# Altium schematic sheet BSPD.SchDoc
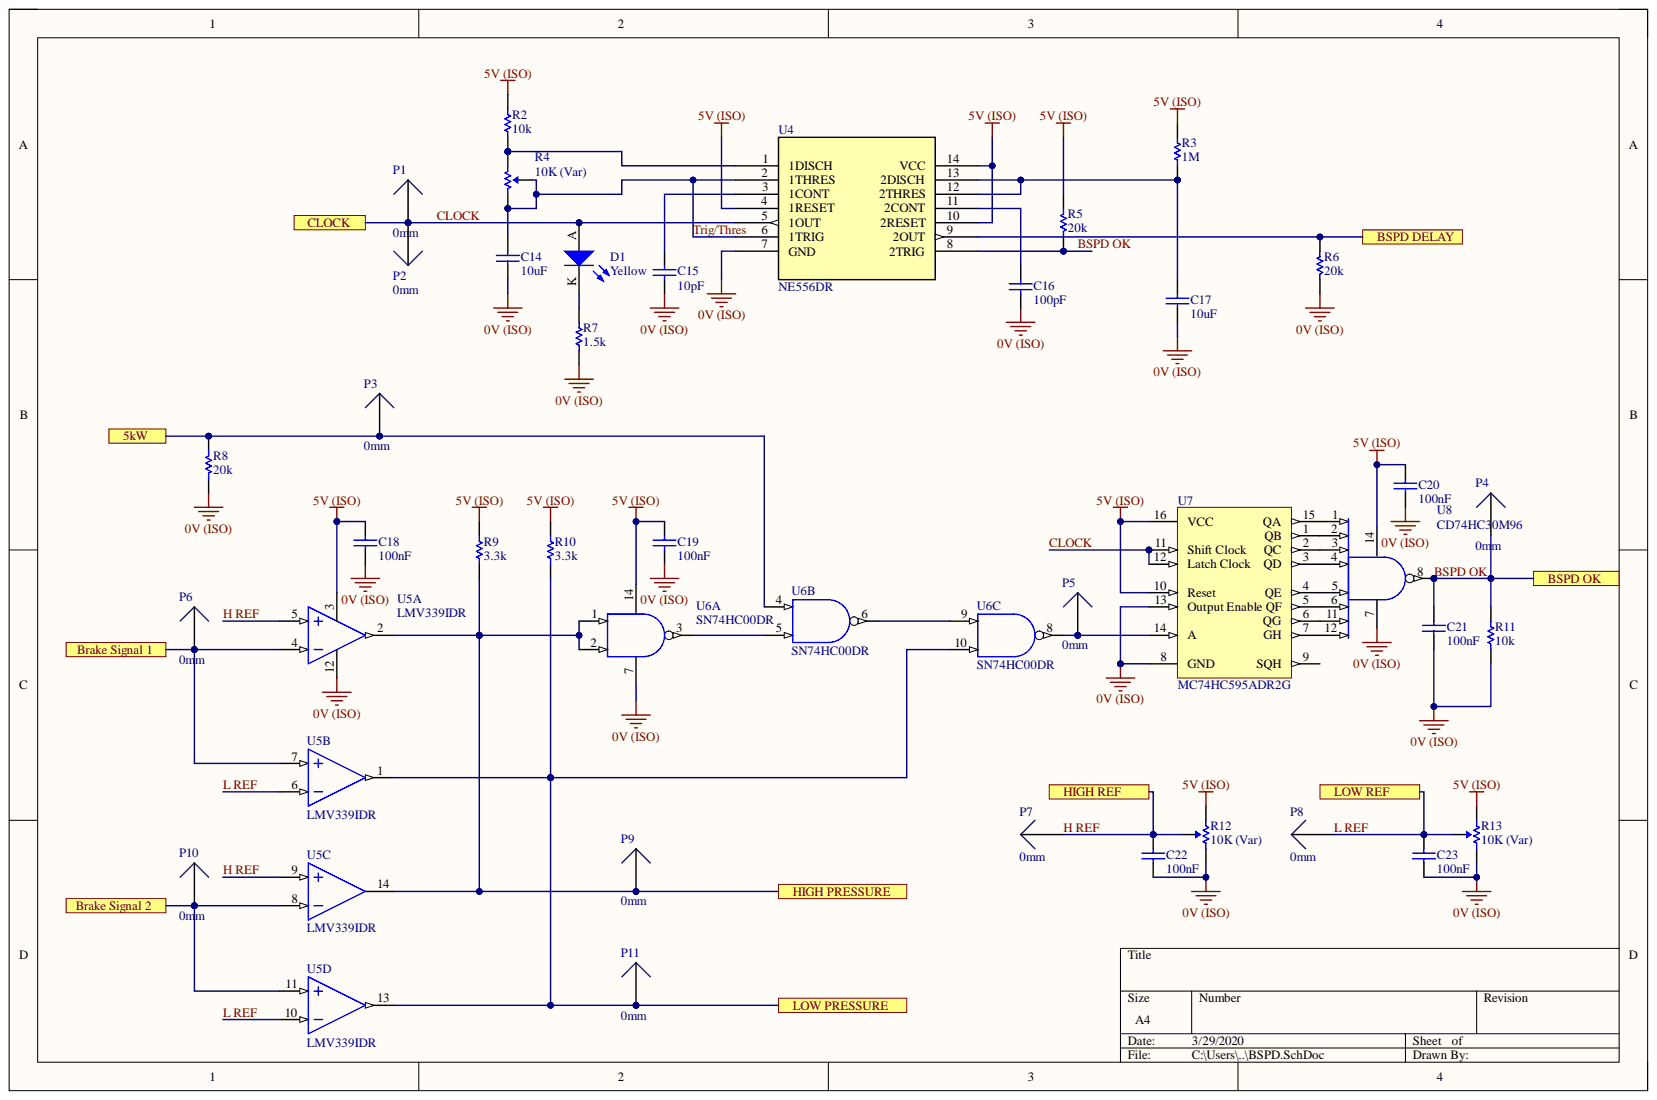
[diagram p0: BSPD.SchDoc]

## Parts
C14  10uF  [0805]
C15  10pF  [0805]
C16  100pF  [0805]
C17  10uF  [0805]
C18  100nF  [0805]
C19  100nF  [0805]
C20  100nF  [0805]
C21  100nF  [0805]
C22  100nF  [0805]
C23  100nF  [0805]
D1  Yellow  [0805 LED]
P1  0mm  [SMD Test Point]
P2  0mm  [TH Test Point]
P3  0mm  [SMD Test Point]
P4  0mm  [SMD Test Point]
P5  0mm  [SMD Test Point]
P6  0mm  [TH Test Point]
P7  0mm  [TH Test Point]
P8  0mm  [TH Test Point]
P9  0mm  [SMD Test Point]
P10  0mm  [TH Test Point]
P11  0mm  [SMD Test Point]
R2  10k  [0805]
R3  1M  [0805]
R4  10K (Var)  [B 3296]
R5  20k  [0805]
R6  20k  [0805]
R7  1.5k  [0805]
R8  20k  [0805]
R9  3.3k  [0805]
R10  3.3k  [0805]
R11  10k  [0805]
R12  10K (Var)  [B 3296]
R13  10K (Var)  [B 3296]
U4  NE556DR  [TI 14-SOIC]
U5  LMV339IDR  [TI 14-SOIC]  (parts A,B,C,D)
U6  SN74HC00DR  [TI 14-SOIC]  (parts A,B,C)
U7  MC74HC595ADR2G  [ON 16-SOIC]
U8  CD74HC30M96  [TI 14-SOIC]

## Ports
5kW, Brake Signal 1, Brake Signal 2, BSPD DELAY, BSPD OK, CLOCK, HIGH PRESSURE, HIGH REF, LOW PRESSURE, LOW REF

## Nets
0V (ISO): C14.1 C15.1 C16.1 C17.1 C18.1 C19.1 C20.1 C21.1 C22.1 C23.1 R6.2 R7.1 R8.2 R11.1 R12.1 R13.1 U4.7(GND) U5.12 U6.7 U7.8(GND) U7.13 U8.7
5V (ISO): C18.2 C19.2 C20.2 R2.2 R3.2 R5.1 R9.2 R10.2 R12.3 R13.3 U4.4(1RESET) U4.10(2RESET) U4.14(VCC) U5.3 U6.14 U7.10(Reset) U7.16(VCC) U8.14
5kW: P3.1 R8.1 U6.4 ; port 5kW
Brake Signal 1: P6.1 U5.4 U5.7 ; port Brake Signal 1
Brake Signal 2: P10.1 U5.8 U5.11 ; port Brake Signal 2
BSPD DELAY: R6.1 U4.9(2OUT) ; port BSPD DELAY
BSPD OK: C21.2 P4.1 R5.2 R11.2 U4.8(2TRIG) U8.8 ; port BSPD OK
CLOCK: D1.A P1.1 P2.1 U4.5(1OUT) U7.11(Shift_Clock) U7.12 ; port CLOCK
H REF: C22.2 P7.1 R12.2 U5.5 U5.9 ; port HIGH REF
HIGH PRESSURE: P9.1 R9.1 U5.2 U5.14 U6.1 U6.2 ; port HIGH PRESSURE
L REF: C23.2 P8.1 R13.2 U5.6 U5.10 ; port LOW REF
LOW PRESSURE: P11.1 R10.1 U5.1 U5.13 U6.10 ; port LOW PRESSURE
Trig/Thres: C14.2 R4.1 R4.2 U4.2(1THRES) U4.6(1TRIG)
NetC15_2: C15.2 U4.3(1CONT)
NetC16_2: C16.2 U4.11(2CONT)
NetC17_2: C17.2 R3.1 U4.12(2THRES) U4.13(2DISCH)
NetD1_K: D1.K R7.2
NetP5_1: P5.1 U6.8 U7.14(A)
NetR2_1: R2.1 R4.3 U4.1(1DISCH)
NetU6_3: U6.3 U6.5
NetU6_6: U6.6 U6.9
NetU7_1: U7.1(QB) U8.2
NetU7_2: U7.2(QC) U8.3
NetU7_3: U7.3(QD) U8.4
NetU7_4: U7.4(QE) U8.5
NetU7_5: U7.5(Enable_QF) U8.6
NetU7_6: U7.6(QG) U8.11
NetU7_7: U7.7(GH) U8.12
NetU7_15: U7.15(QA) U8.1

Not connected: U7 9(SQH)

## Library data of the parts
C14 C17 = GRM21BR6YA106KE43L: Manufacturer: muRata; Database Table Name: Capacitors$; Material: X5R; Rated Voltage: 50V; Tolerance: 10%; Component Kind: Standard; Digikey Part Number: 490-10514-6-ND; Library Name: ts_20-standard-library.DbLib; Part Number: GRM21BR6YA106KE43L; Value: 10uF
C15 = GRM21A5C2E100JW01D: Manufacturer: muRata; Database Table Name: Capacitors$; Material: C0G, NP0; Rated Voltage: 250V; Tolerance: ±5%; Component Kind: Standard; Digikey Part Number: 490-5530-1-ND; Library Name: ts_20-standard-library.DbLib; Part Number: GRM21A5C2E100JW01D; Value: 10pF
C16 = GCM2165C1H101JA16D: Manufacturer: muRata; Database Table Name: Capacitors$; Material: C0G, NP0; Rated Voltage: 50V; Tolerance: ±5%; Component Kind: Standard; Digikey Part Number: 490-8031-1-ND; Library Name: ts_20-standard-library.DbLib; Part Number: GCM2165C1H101JA16D; Value: 100pF
C18 C20-C23 = GCM21BR71H104KA37L: Manufacturer: muRata; Database Table Name: Capacitors$; Material: X7R; Rated Voltage: 50V; Tolerance: ±10%; Component Kind: Standard; Digikey Part Number: 490-6064-1-ND; Library Name: ts_20-standard-library.DbLib; Part Number: GCM21BR71H104KA37L; Value: 100nF
C19 = GCM21BR71E104KA37L: Manufacturer: muRata; Database Table Name: Capacitors$; Material: X7R; Rated Voltage: 25V; Tolerance: 10%; Component Kind: Standard; Library Name: ts_20-standard-library.DbLib; Part Number: GCM21BR71E104KA37L; Value: 100nF
D1 = SML-211YTT86: Yellow LED Indication - Discrete 1.75V 0805 (2012 Metric); Manufacturer: ROHM Semiconductor; Database Table Name: LEDs$; Colour: Yellow; Component Kind: Standard; Digikey Link: https://www.digikey.com/product-detail/en/rohm-semiconductor/SML-211YTT86/511-1293-1-ND/637106; Digikey Part Number: 511-1293-1-ND; Library Name: ts_20-standard-library.DbLib; Part Number: SML-211YTT86
P1 P3-P5 P9 P11 = SMD Test Point: Angle: 0; Contact: NA; Database Table Name: Generic$; Flange: No; Positions: 1; Component Kind: Standard; Library Name: ts_20-standard-connectors.DbLib; Part Number: SMD Test Point; Pitch: 0mm; Row Spacing: 0
P2 P6-P8 P10 = TH Test Point: Angle: 0; Contact: NA; Database Table Name: Generic$; Flange: No; Positions: 1; Component Kind: Standard; Library Name: ts_20-standard-connectors.DbLib; Part Number: TH Test Point; Pitch: 0mm; Row Spacing: 0mm
R2 R11 = RC0805FR0710KL: Manufacturer: YAGEO; Database Table Name: Resistors$; Rated Voltage: 0.125W; Tolerance: 0.01; Component Kind: Standard; Library Name: ts_20-standard-library.DbLib; Material: FR-07; Part Number: RC0805FR0710KL; Value: 10k
R3 = RC0805FR071ML: Manufacturer: YAGEO; Database Table Name: Resistors$; Rated Voltage: 0.125W; Tolerance: 0.01; Component Kind: Standard; Library Name: ts_20-standard-library.DbLib; Material: FR-07; Part Number: RC0805FR071ML; Value: 1M
R4 R12 R13 = 3296W-1-103LF: Manufacturer: Bournes Inc; Database Table Name: Resistors$; Rated Voltage: 0.5W; Tolerance: 0.1; Component Kind: Standard; Digikey Part Number: 3296W-103LF-ND; Library Name: ts_20-standard-library.DbLib; Part Number: 3296W-1-103LF; Value: 10K (Var)
R5 R6 R8 = RC0805FR0720KL: Manufacturer: YAGEO; Database Table Name: Resistors$; Rated Voltage: 0.125W; Tolerance: 0.01; Component Kind: Standard; Library Name: ts_20-standard-library.DbLib; Material: FR-07; Part Number: RC0805FR0720KL; Value: 20k
R7 = RC0805FR071K5L: Manufacturer: YAGEO; Database Table Name: Resistors$; Rated Voltage: 0.125W; Tolerance: 0.01; Component Kind: Standard; Library Name: ts_20-standard-library.DbLib; Material: FR-07; Part Number: RC0805FR071K5L; Value: 1.5k
R9 R10 = RC0805FR073K3L: Manufacturer: YAGEO; Database Table Name: Resistors$; Rated Voltage: 0.125W; Tolerance: 0.01; Component Kind: Standard; Library Name: ts_20-standard-library.DbLib; Material: FR-07; Part Number: RC0805FR073K3L; Value: 3.3k
U4 = NE556DR: IC OSC TIMER DUAL 100KHZ 14SOIC; Manufacturer: Texas Instruments; Database Table Name: Integrated Components$; Component Kind: Standard; Digikey Link: https://www.digikey.com/product-detail/en/texas-instruments/NE556DR/296-26240-1-ND/2254905; Digikey Part Number: 296-26240-1-ND; Library Name: ts_20-standard-library.DbLib; Part Number: NE556DR
U5 = LMV339IDR: Comparator General Purpose Open Collector 14-SOIC; Manufacturer: Texas Instruments; Database Table Name: Integrated Components$; Component Kind: Standard; Digikey Link: https://www.digikey.com/product-detail/en/texas-instruments/LMV339MTX-NOPB/296-35446-1-ND/3739150; Digikey Part Number: 296-6611-2-ND; Library Name: ts_20-standard-library.DbLib; Part Number: LMV339IDR
U6 = SN74HC00DR: NAND Gate IC 4 Channel 14-SOIC; Manufacturer: Texas Instruments; Database Table Name: Integrated Components$; Component Kind: Standard; Digikey Link: https://www.digikey.com.au/product-detail/en/texas-instruments/SN74HC00DR/296-1187-2-ND/276830; Digikey Part Number: 296-1187-2-ND; Library Name: ts_20-standard-library.DbLib; Part Number: SN74HC00DR
U7 = MC74HC595ADR2G: IC SHIFT REGISTR 8BIT 3ST 16SOIC; Manufacturer: ON Semiconductor; Database Table Name: Integrated Components$; Component Kind: Standard; Digikey Link: https://www.digikey.com/product-detail/en/on-semiconductor/MC74HC595ADR2G/MC74HC595ADR2GOSCT-ND/1139727; Digikey Part Number: MC74HC595ADR2GOSCT-ND; Library Name: ts_20-standard-library.DbLib; Part Number: MC74HC595ADR2G
U8 = CD74HC30M96: IC GATE NAND 1CH 8-INP 14SOIC; Manufacturer: Texas Instruments; Database Table Name: Integrated Components$; Component Kind: Standard; Digikey Link: https://www.digikey.com/product-detail/en/texas-instruments/CD74HC30M96/296-14521-1-ND/555580; Digikey Part Number: 296-14521-1-ND; Library Name: ts_20-standard-library.DbLib; Part Number: CD74HC30M96
